# Altium schematic sheet Sheet4.SchDoc
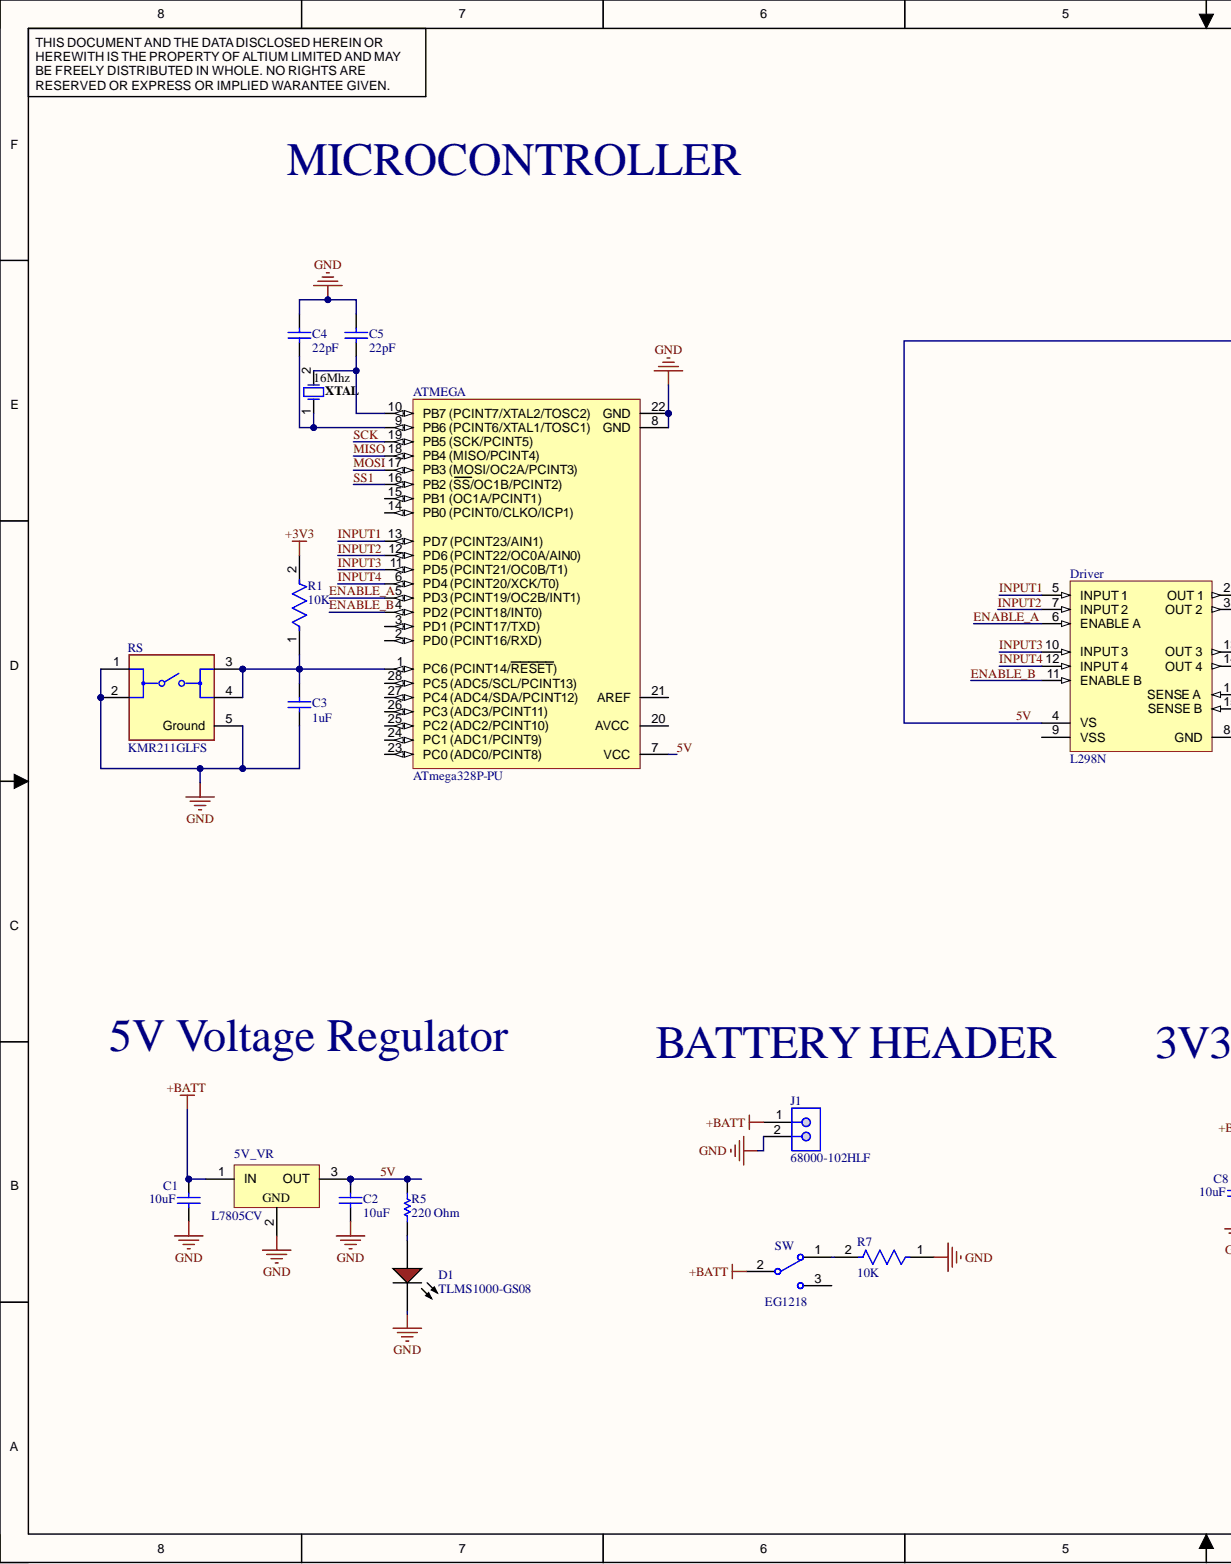
[diagram p0: Sheet4.SchDoc, left]
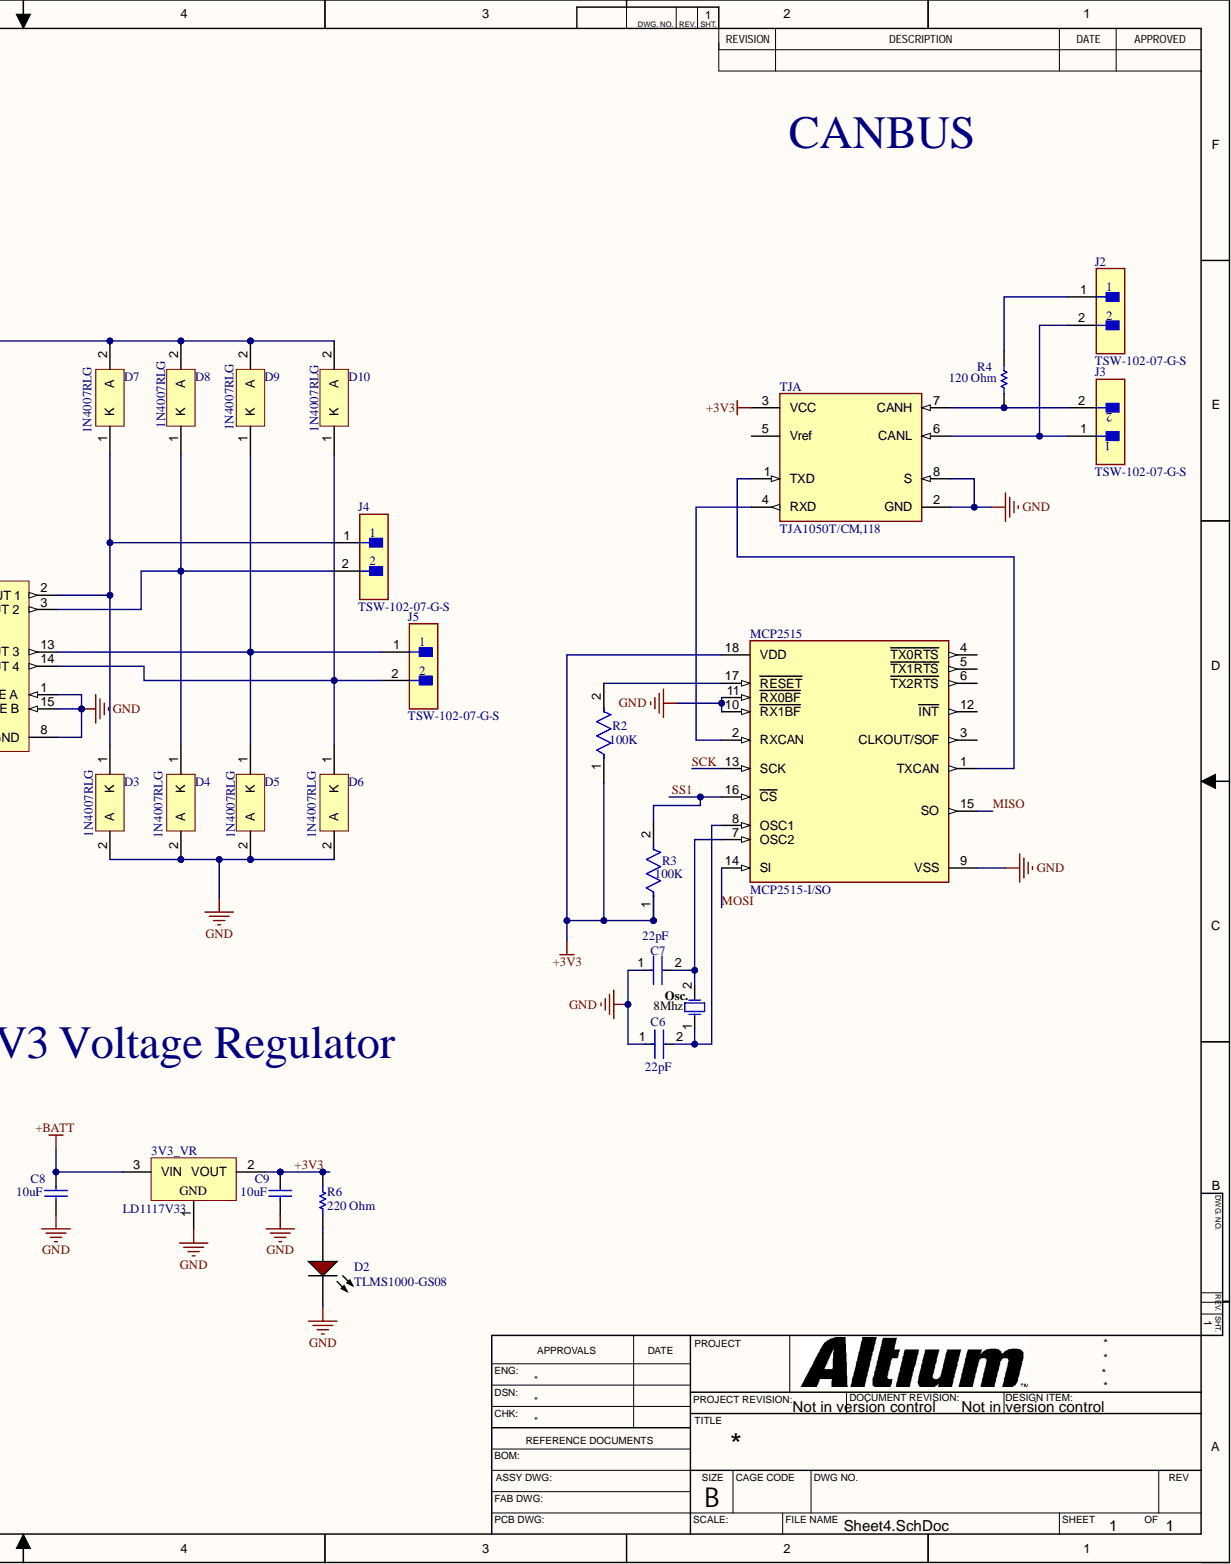
[diagram p1: Sheet4.SchDoc, right]

## Parts
3V3_VR  LD1117V33  [TO220]
5V_VR  L7805CV  [TO220]
ATMEGA  ATmega328P-PU  [28P3]
C1  10uF  [CAPC2013X135X45NL10T25]
C2  10uF  [CAPC2013X135X45NL10T25]
C3  1uF  [CAPC1608X90X35ML10T25]
C4  22pF  [CAPC1508X91X25NL25T13]
C5  22pF  [CAPC1508X91X25NL25T13]
C6  22pF  [FP-C0805C-DN-MFG]
C7  22pF  [FP-C0805C-DN-MFG]
C8  10uF  [CAPC2013X135X45NL10T25]
C9  10uF  [CAPC2013X135X45NL10T25]
D1  TLMS1000-GS08  [VISH-TLMS1000_V]
D2  TLMS1000-GS08  [VISH-TLMS1000_V]
D3  1N4007RLG  [ONSC-AXIAL_LEAD-2-59-10_P]
D4  1N4007RLG  [ONSC-AXIAL_LEAD-2-59-10_P]
D5  1N4007RLG  [ONSC-AXIAL_LEAD-2-59-10_P]
D6  1N4007RLG  [ONSC-AXIAL_LEAD-2-59-10_P]
D7  1N4007RLG  [ONSC-AXIAL_LEAD-2-59-10_P]
D8  1N4007RLG  [ONSC-AXIAL_LEAD-2-59-10_P]
D9  1N4007RLG  [ONSC-AXIAL_LEAD-2-59-10_P]
D10  1N4007RLG  [ONSC-AXIAL_LEAD-2-59-10_P]
Driver  L298N  [STM-FM-15]
J1  68000-102HLF  [AMPH-68000-102HLF_V]
J2  TSW-102-07-G-S  [FP-TSW-102-07-G-S-MFG]
J3  TSW-102-07-G-S  [FP-TSW-102-07-G-S-MFG]
J4  TSW-102-07-G-S  [FP-TSW-102-07-G-S-MFG]
J5  TSW-102-07-G-S  [FP-TSW-102-07-G-S-MFG]
MCP2515  MCP2515-I/SO  [FP-C04-051-MFG]
Osc.  8Mhz  [FOX-FOXSLF080-20]
R1  10K  [FP-CPF0603-MFG]
R2  100K  [FP-CPF0603-MFG]
R3  100K  [FP-CPF0603-MFG]
R4  120 Ohm  [RESC1608X55X25NL10T15]
R5  220 Ohm  [RESC1608X55X25ML10T15]
R6  220 Ohm  [RESC1608X55X25ML10T15]
R7  10K  [FP-CPF0603-MFG]
RS  KMR211GLFS  [FP-KMR211GLFS-MFG]
SW  EG1218  [ESWI-EG1218_V]
TJA  TJA1050T/CM,118  [FP-SOT96-1-IPC_A]
XTAL  16Mhz  [FOX-FOXSLF160-20]

## Nets
+BATT: 3V3_VR.3 5V_VR.1 C1.1 C8.1 J1.1 SW.2
GND: 3V3_VR.1 5V_VR.2 ATMEGA.8(GND) ATMEGA.22(GND) C1.2 C2.2 C3.1 C4.2 C5.2 C6.1 C7.1 C8.2 C9.2 D1.2 D2.2 D3.2 D4.2 D5.2 D6.2 Driver.1(SENSE_A) Driver.8(GND) Driver.15(SENSE_B) J1.2 MCP2515.9(VSS) MCP2515.10(RX1BF) MCP2515.11(RX0BF) R7.1 RS.1 RS.2 RS.5(Ground) TJA.2(GND) TJA.8(S)
5V: 5V_VR.3 ATMEGA.7(VCC) C2.1 D7.2 D8.2 D9.2 D10.2 Driver.4(VS) R5.2
+3V3: 3V3_VR.2 C9.1 MCP2515.18(VDD) R1.2 R2.1 R3.1 R6.2 TJA.3(VCC)
ENABLE_A: ATMEGA.5(PD3_(PCINT19/OC2B/INT1)) Driver.6(ENABLE_A)
ENABLE_B: ATMEGA.4(PD2_(PCINT18/INT0)) Driver.11(ENABLE_B)
INPUT1: ATMEGA.13(PD7_(PCINT23/AIN1)) Driver.5(INPUT_1)
INPUT2: ATMEGA.12(PD6_(PCINT22/OC0A/AIN0)) Driver.7(INPUT_2)
INPUT3: ATMEGA.11(PD5_(PCINT21/OC0B/T1)) Driver.10(INPUT_3)
INPUT4: ATMEGA.6(PD4_(PCINT20/XCK/T0)) Driver.12(INPUT_4)
MISO: ATMEGA.18(PB4_(MISO/PCINT4)) MCP2515.15(SO)
MOSI: ATMEGA.17(PB3_(MOSI/OC2A/PCINT3)) MCP2515.14(SI)
SCK: ATMEGA.19(PB5_(SCK/PCINT5)) MCP2515.13(SCK)
SS1: ATMEGA.16(PB2_(SS/OC1B/PCINT2)) MCP2515.16(CS) R3.2
NetATMEGA_1: ATMEGA.1(PC6_(PCINT14/RESET)) C3.2 R1.1 RS.3 RS.4
NetATMEGA_9: ATMEGA.9(PB6_(PCINT6/XTAL1/TOSC1)) C4.1 XTAL.1
NetATMEGA_10: ATMEGA.10(PB7_(PCINT7/XTAL2/TOSC2)) C5.1 XTAL.2
NetC6_2: C6.2 MCP2515.8(OSC1) Osc..1
NetC7_2: C7.2 MCP2515.7(OSC2) Osc..2
NetD1_1: D1.1 R5.1
NetD2_1: D2.1 R6.1
NetD3_1: D3.1 D7.1 Driver.2(OUT_1) J4.1
NetD4_1: D4.1 D8.1 Driver.3(OUT_2) J4.2
NetD5_1: D5.1 D9.1 Driver.13(OUT_3) J5.1
NetD6_1: D6.1 D10.1 Driver.14(OUT_4) J5.2
NetJ2_1: J2.1 R4.2
NetJ2_2: J2.2 J3.1 TJA.6(CANL)
NetJ3_2: J3.2 R4.1 TJA.7(CANH)
NetMCP2515_1: MCP2515.1(TXCAN) TJA.1(TXD)
NetMCP2515_2: MCP2515.2(RXCAN) TJA.4(RXD)
NetMCP2515_17: MCP2515.17(RESET) R2.2
NetR7_2: R7.2 SW.1

Not connected: ATMEGA 2(PD0_(PCINT16/RXD)) 3(PD1_(PCINT17/TXD)) 14(PB0_(PCINT0/CLKO/ICP1)) 15(PB1_(OC1A/PCINT1)) 20(AVCC) 21(AREF) 23(PC0_(ADC0/PCINT8)) 24(PC1_(ADC1/PCINT9)) 25(PC2_(ADC2/PCINT10)) 26(PC3_(ADC3/PCINT11)) 27(PC4_(ADC4/SDA/PCINT12)) 28(PC5_(ADC5/SCL/PCINT13)); Driver 9(VSS); MCP2515 3(CLKOUT/SOF) 4(TX0RTS) 5(TX1RTS) 6(TX2RTS) 12(INT); SW 3; TJA 5(Vref)

## Library data of the parts
3V3_VR = CMP-0244-00470-1: Fixed Low Drop Positive Voltage Regulator, 3.3V, 3-Pin TO-220; Component Kind: Standard; ComponentLink1Description: Manufacturer URL; ComponentLink1URL: http://www.st.com; ComponentLink2Description: Datasheet; ComponentLink2URL: http://www.st.com/internet/com/TECHNICAL_RESOURCES/TECHNICAL_LITERATURE/DATASHEET/CD00000544.pdf; ComponentLink3Description: Package Specification; ComponentLink3URL: http://www.st.com/internet/com/TECHNICAL_RESOURCES/TECHNICAL_LITERATURE/PACKAGE_INFORMATION/CD00004649.pdf; DatasheetVersion: 1/11/2011; Library Name: Altium Content Vault; PackageDescription: TO, Thru-Hole, Vertical, Heatsink Mounted; 3 In-Line Leads; Pitch 2.54 mm; PackageReference: TO220; PackageVersion: ver3.0
5V_VR = CMP-0244-00483-1: Positive Voltage Regulator, 5V, 3-Pin TO-220; Component Kind: Standard; ComponentLink1Description: Manufacturer URL; ComponentLink1URL: http://www.st.com; ComponentLink2Description: Datasheet; ComponentLink2URL: http://www.st.com/internet/com/TECHNICAL_RESOURCES/TECHNICAL_LITERATURE/DATASHEET/CD00000444.pdf; ComponentLink3Description: Package Specification; ComponentLink3URL: http://www.st.com/internet/com/TECHNICAL_RESOURCES/TECHNICAL_LITERATURE/PACKAGE_INFORMATION/CD00004649.pdf; DatasheetVersion: 16/11/2011; Library Name: Altium Content Vault; PackageDescription: TO, Thru-Hole, Vertical, Heatsink Mounted; 3 In-Line Leads; Pitch 2.54 mm; PackageReference: TO220; PackageVersion: ver3.0
ATMEGA = CMP-0095-00013-2: 8-bit AVR Microcontroller, 32KB Flash, 1KB EEPROM, 2KB SRAM, 28-pin PDIP, Industrial Grade (-40°C to 85°C); Component Kind: Standard; ComponentLink1Description: Manufacturer URL; ComponentLink1URL: http://www.atmel.com/; ComponentLink2Description: ATmega48A/48PA/88A/88PA/168A/168PA/328/328P Datasheet; ComponentLink2URL: http://www.atmel.com/dyn/resources/prod_documents/8271.pdf; ComponentLink3Description: ATmega48A/48PA/88A/88PA/168A/168PA/328/328P Summary; ComponentLink3URL: http://www.atmel.com/dyn/resources/prod_documents/8271S.pdf; DatasheetVersion: revD, May-2011; Library Name: Altium Content Vault; PackageDescription: 28-lead (0.300"/7.62mm Wide) Plastic Dual Inline Package (PDIP); PackageReference: 28P3; PackageVersion: revB, Sep-2001; RevisionNote: DatasheetVersion updated. URLs added.
C1 C2 C8 C9 = CMP-2007-03463-1: Manufacturer: Murata; Part Number: GRM21BR61A106KE19K; Component Kind: Standard; Library Name: Altium Content Vault
C3 = CMP-2000-05447-1: Chip Capacitor, 1 uF, +/- 10%, 50 V, -55 to 85 degC, 0603 (1608 Metric), RoHS, Tape and Reel; Manufacturer: Taiyo Yuden; Capacitance: 1µF; Catalog Drawings: xMKSeries_0603_0.8; Online Catalog: MSeries; Other Names: 587-2400-6; Temperature Coefficient: X5R; Voltage - Rated: 50V; Applications: GeneralPurpose; Category: Capacitors; Component Kind: Standard; Datasheet URL: https://datasheet.ciiva.com/15922/336umk107bj105ka-t-15922611.pdf; Datasheet Version: Mar-13; Family: CeramicCapacitors; Features: -; Height - Seated (Max): -; Lead Spacing: -; Lead Style: -; Library Name: Altium Content Vault; Manufacturer URL: http://www.t-yuden.com/; Mounting Type: SurfaceMount,MLCC; Operating Temperature: -55degC~85degC; Package / Case: 0603(1608Metric); Package Description: 2-Pin Surface Mount Device, Body 1.6 x 0.8 mm; Package Reference: 603; Packaging: Digi-Reel; Ratings: -; Series: M; Size / Dimension: 0.063"Lx0.031"W(1.60mmx0.80mm); Standard Package: 1; Thickness (Max): 0.035"(0.90mm); Tolerance: ±10%
C4 C5 = CMP-2006-04643-1: Manufacturer: AVX Interconnect / Elco; Part Number: 06031U220FAT2A; Component Kind: Standard; Library Name: Altium Content Vault
C6 C7 = CMP-2007-00466-2: CAP CER 22PF 50V 10% NP0 0805; Manufacturer: KEMET; Manufacturer Part Number: C0805C220K5GACTU; Material: Ceramic; Temperature Characteristic: C0G; Temperature Coefficient: 30ppm/°C; Tolerance: 0.1; Automotive: No; Capacitance: 22 pF; Category: Cap; Component Kind: Standard; ComponentLink2Description: Datasheet; ComponentLink2URL: https://content.kemet.com/datasheets/KEM_C1003_C0G_SMD.pdf; Device Class L1: Passive Components; Device Class L2: Capacitors; Device Class L3: Ceramic Capacitors; Digikey Description: CAP CER 22PF 50V 10% NP0 0805; Height: 0.88mm; Ipc Land Pattern Name: CAPC200125X78; Lead Free: Yes; Library Name: Altium Content Vault; Mouser Description: Multilayer Ceramic Capacitors MLCC - SMD/SMT 50volts 22pF C0G 10%; Octopart: https://octopart.com/c0805c220k5gactu-kemet-9138623; Package: C0805C-DN; RoHS: TRUE; Temperature: -55°C to +125°C; Temperature Range High: +125°C; Temperature Range Low: -55°C; Value: 22 pF; Voltage Rating: 50 V
D1 D2 = CMP-2000-07254-1: Low Current LED, 40 mW, 1.8 V, -40 to 100 degC, 2-Pin SMD (0603), RoHS, Tape and Reel; Manufacturer: Vishay; Automotive Qualification Standard: AEC-Q100; Bulb Size: 1.6mmx0.8mm; Forward Current If: 2mA; Forward Voltage: 1.8V; LED Colour: Red; Lens Shape: Rectangular; Luminous Intensity: 4mcd; SVHC: ToBeAdvised; Viewing Angle: 80°; Wavelength Typ: 636nm; Component Kind: Standard; Datasheet URL: https://datasheet.ciiva.com/5798/1698881-5798337.pdf; Datasheet Version: Rev. 1.7, 02/2011; LED Mounting: SMD; Library Name: Altium Content Vault; Manufacturer URL: http://www.vishay.com/; Mounting Technology: SurfaceMount; MSL: MSL2-1year; Package Description: 2-Pin Surface Mount Device, Body 1.6 x 0.8 mm; Package Reference: TLMS1000; Packaging: CutTape; Product Range: -
D3-D10 = CMP-0902-00147-1: Standard Recovery Rectifier, 2-Pin Axial_Lead, Pb-Free, Tape and Reel; Manufacturer: On Semiconductor; IFSM Max (A): 30; IO(rec) Max (A): 1; VRRM Max (V): 1000; Component Kind: Standard; ComponentLink1Description: Manufacturer URL; ComponentLink1URL: http://www.onsemi.com/; ComponentLink2Description: Datasheet; ComponentLink2URL: http://www.onsemi.com/pub_link/Collateral/1N4001-D.PDF; ComponentLink3Description: Package Specification; ComponentLink3URL: http://www.onsemi.cn/pub_link/Collateral/59-10.PDF; DatasheetVersion: Rev. 13; Library Name: Altium Content Vault; Mounting Technology: Through Hole; PackageDescription: 2-Pin, Axial Lead, Diameter 2.35 mm; PackageReference: AXIAL_LEAD-2-59-10; PackageVersion: Rev. U, 02/2005; Packing: Tape and Reel; PartNumber: 1N4007RLG; RoHS: TRUE; Type: Standard Recovery
Driver = CMP-2000-06526-1: Dual Full-Bridge Driver, 4.8 to 46 V, -40 to 150 degC, 15-Pin FM (MW 15L), RoHS, Tube; Manufacturer: STMicroelectronics; PartNumber: L298; Driver Case Style: Multiwatt; Motor Type: FullBridge; No. of Outputs: 4Outputs; No. of Pins: 15Pins; Output Current: 2A; Output Voltage: 46V; Supply Voltage Max: 7V; Supply Voltage Min: 4.5V; SVHC: NoSVHC(17-Dec-2015); Automotive Qualification Standard: -; Component Kind: Standard; Datasheet URL: https://datasheet.ciiva.com/15109/getdatasheetpartid-50682-15109332.pdf; Datasheet Version: 01/2000; Library Name: Altium Content Vault; Manufacturer URL: http://www.st.com/; Mounting Technology: ThroughHole; MSL: -; Operating Temperature Max: 130°C; Operating Temperature Min: -25°C; Package Description: 15-Pin Flange Mount, Body 5 x 19.6 mm, Pitch 1.27 mm; Package Reference: FM-15; Packaging: Each; Product Range: -
J1 = CMP-2000-05666-1: Male Header, Pitch 2.54 mm, 1 x 2 Position, Height 8.38 mm, Tail Length 2.41 mm, RoHS, Bulk; Brand: FCI; Current Rating: 3A; Insulation Resistance: 5000MOhms; Mating Post Length: 5.84mm; Mounting Angle: Vertical; Number of Positions: 2; Pitch: 2.54mm; Voltage Rating: 1.5kV; Component Kind: Standard; Contact Gender: Pin(Male); Contact Material: PhosphorBronze; Contact Plating: Gold/GTX; Datasheet URL: https://datasheet.ciiva.com/5149/68000-274228-5149165.pdf; Datasheet Version: Rev. F, 04/2006; Factory Pack Quantity: 100; Flammability Rating: UL94V-0; Housing Material: Thermoplastic; Library Name: Altium Content Vault; Manufacturer: FCI; Manufacturer URL: http://www.amphenol.com/; Mounting Style: ThroughHole; Mounting Technology: ThroughHole; Number of Positions / Contacts: 2; Number of Rows: 1; Operating Temperature Range: -65Cto+125C; Package Description: Male Header, Pitch 2.54 mm, 1 x 2 Position, Height 8.38 mm, Tail Length 2.41 mm; Package Reference: 68000-102HLF; Packaging: Bulk; Product Category: Headers&WireHousings; Product Type: Headers; RoHS: Details; Series: 68000; Termination Post Length: 2.41mm; Termination Style: ThroughHole; Type: Unshrouded
J2-J5 = CMP-02766-000084-1: CONN HEADER VERT 2POS 2.54MM; Manufacturer: Samtec; Conn Gender: Male; Conn Orientation: Straight; Current Rating: 6.3A; Number Of Contacts: 2; Voltage Rating: 550V; Automotive: No; Category: Conn; Component Kind: Standard; ComponentLink2Description: Datasheet; ComponentLink2URL: http://suddendocs.samtec.com/catalog_english/tsw_th.pdf; Contact Material: Gold,Nickel; Device Class L1: Connectors; Device Class L2: Headers and Wire Housings; Device Class L3: unset; Digikey Description: CONN HEADER VERT 2POS 2.54MM; Footprint Url: http://suddendocs.samtec.com/prints/tsw-xxx-xx-x-x-xx-xxx-footprint.pdf; Height: 8.71mm; Lead Free: Yes; Library Name: Altium Content Vault; Manufacturer Part Number: TSW-102-07-G-S; Mouser Description: Conn Unshrouded Header HDR 2 POS 2.54mm Solder ST Thru-Hole Automotive Bulk; Number Of Rows: 1; Octopart: https://octopart.com/tsw-102-07-g-s-samtec-272197; Package: TSW-102-07-G-S; Pitch: 2.54mm; RoHS: TRUE; Temperature: -55°C to +125°C; Temperature Range High: +125°C; Temperature Range Low: -55°C
MCP2515 = CMP-0186-00133-3: IC CAN CONTROLLER W/SPI 18SOIC; Manufacturer: Microchip; Interface: SPI,CAN; Max Supply Voltage: 5.5V; Min Supply Voltage: 2.7V; Nominal Supply Current: 10mA; Standoff Height: 0.1mm; Ambient Temperature Range High: +125°C; Ambient Temperature Range Low: -65°C; Automotive: No; Category: IC; Component Kind: Standard; ComponentLink2Description: Datasheet; ComponentLink2URL: http://ww1.microchip.com/downloads/en/DeviceDoc/MCP2515-Stand-Alone-CAN-Controller-with-SPI-20001801J.pdf; Device Class L1: Integrated Circuits (ICs); Device Class L2: Interface ICs; Device Class L3: Controllers; Digikey Description: IC CAN CONTROLLER W/SPI 18SOIC; Footprint Url: http://ww1.microchip.com/downloads/en/DeviceDoc/18L_SOIC_Wide_7.50_SO_C04-0051D.pdf; Function: Controller; Height: 2.65mm; Ipc Land Pattern Name: SOIC127P1030X265-18; Lead Free: Yes; Library Name: Altium Content Vault; Manufacturer Part Number: MCP2515-I/SO; Mouser Description: CAN Interface IC W/ SPI Interface; Octopart: https://octopart.com/mcp2515-i%2Fso-microchip-430187; Package: C04-051; RoHS: TRUE; Temperature: -40°C to +85°C; Temperature Range High: +85°C; Temperature Range Low: -40°C
Osc. = CMP-1749-00002-1: Resistance Weld Thru-Hole Crystal, 8 MHz, -20 to 70 degC, 2-Pin THD, RoHS, Bulk; Case\Package: TO-263-3; Dropout Voltage: 2 V; Max Input Voltage: 35 V; Max Ouput Current: 1 A; Min Input Voltage: 7 V; Nominal Output Voltage: 5 V; Outputs: 1; Component Kind: Standard; ComponentLink1Description: Manufacturer URL; ComponentLink1URL: http://www.foxonline.com/; ComponentLink2Description: Datasheet; ComponentLink2URL: http://download.siliconexpert.com/pdfs/2015/4/5/6/5/7/200/fox_/manual/35607121449963728hc49slf.pdf; DatasheetVersion: Mar-15; Height: 30.02 mm; Length: 10.24 mm; Library Name: Altium Content Vault; Max Operating Temperature: 125 degC; Min Operating Temperature: 0 degC; Mount: Surface Mount; Output Current: 1 A; Output Voltage: 5 V; Package Quantity: 50; PackageDescription: 2-Pin Through Hole Device, Body 11.35 x 4.65 mm; PackageReference: FOXSLF080-20; Packaging: Rail/Tube; Pins: 3; Radiation Hardening: No; REACH SVHC: No SVHC; RoHSCompliant: true; Width: 9.35 mm
R1 R7 = CMP-03211-008304-1: RES SMD 10KΩ 1% 1/16W 0603; Manufacturer: TE Connectivity; Manufacturer Part Number: CPF0603F10KC1; Power Rating: 0.063W; Temperature Coefficient: 50ppm/°C; Voltage Rating: 50V; Automotive: No; Category: Res; Component Kind: Standard; ComponentLink2Description: Datasheet; ComponentLink2URL: https://www.te.com/commerce/DocumentDelivery/DDEController?Action=showdoc&DocId=Data+Sheet%7F1773200%7FM%7Fpdf%7FEnglish%7FENG_DS_1773200_M.pdf%7F3-1676481-2; Composition: Thin Film; Device Class L1: Passive Components; Device Class L2: Resistors; Device Class L3: Chip SMD Resistors; Height: 0.55mm; Ipc Land Pattern Name: RESC15580X45; Lead Free: Yes; Library Name: Altium Content Vault; Octopart: https://octopart.com/cpf0603f10kc1-te+connectivity-42013076; octopart_url: https://octopart.com/cpf0603f10kc1-te+connectivity-42013076; Package: CPF0603; packaging: 1k Reel; Resistance: 10KΩ; RoHS: TRUE; Series: CPF; Temperature: -55°C to +155°C; Temperature Range High: +155°C; Temperature Range Low: -55°C; Tolerance: 1%; Value: 10KΩ
R2 R3 = CMP-03211-008043-1: RES SMD 100KΩ 0.1% 1/16W 0603; Manufacturer: TE Connectivity; Manufacturer Part Number: CPF0603B100KE1; Power Rating: 0.063W; Temperature Coefficient: 25ppm/°C; Voltage Rating: 50V; Automotive: No; Category: Res; Component Kind: Standard; ComponentLink2Description: Datasheet; ComponentLink2URL: https://www.te.com/commerce/DocumentDelivery/DDEController?Action=showdoc&DocId=Data+Sheet%7F1773200%7FM%7Fpdf%7FEnglish%7FENG_DS_1773200_M.pdf%7F3-1676481-2; Composition: Thin Film; Device Class L1: Passive Components; Device Class L2: Resistors; Device Class L3: Chip SMD Resistors; Height: 0.55mm; Ipc Land Pattern Name: RESC15580X45; Lead Free: Yes; Library Name: Altium Content Vault; Octopart: https://octopart.com/cpf0603b100ke1-te+connectivity-9539443; octopart_url: https://octopart.com/cpf0603b100ke1-te+connectivity-9539443; Package: CPF0603; packaging: 1k Reel; Resistance: 100KΩ; RoHS: TRUE; Series: CPF; Temperature: -55°C to +155°C; Temperature Range High: +155°C; Temperature Range Low: -55°C; Tolerance: 0.1%; Value: 100KΩ
R4 = CMP-1659-00034-1: Chip Resistor, 120 Ohm, +/- 1%, 100 mW, -55 to 155 degC, 0603 (1608 Metric), RoHS, Tape and Reel; Case\Package: 0603; Forward Current: 20 mA; Forward Voltage: 2.1 V; Illumination Colour: Orange; Lens Style: Diffused; Luminous Intensity: 80 mcd; Nominal Wavelength: 606 nm; Power Rating: 90 mW; Viewing Angle: 160 degrees; Case Code (Imperial): 0603; Case Code (Metric): 1608; Colour: Orange; Component Kind: Standard; ComponentLink1Description: Manufacturer URL; ComponentLink1URL: http://www.yageo.com/; ComponentLink2Description: Datasheet; ComponentLink2URL: http://www.yageo.com/documents/recent/PYu-RC_Group_51_RoHS_L_04.pdf; DatasheetVersion: Rev. 4, 02/2016; Height: 0.6 mm; Length: 1.6 mm; Library Name: Altium Content Vault; Max Operating Temperature: 100 degC; Min Operating Temperature: -40 degC; Mount: Surface Mount; Nominal Vf: 2.1 V; Package Quantity: 3000; PackageDescription: 2-Pin Surface Mount Device, Body 1.6 x 0.8 mm; PackageReference: 0603; Packaging: Tape and Reel; Pins: 2; Radiation Hardening: No; REACH SVHC: Unknown; RoHSCompliant: true; Width: 0.8 mm
R5 R6 = CMP-1659-00018-2: General Purpose Chip Resistors, 220 Ohm, +/- 1%, -55 to 155 degC, 0603 (1608 Metric), RoHS, Tape and Reel; Component Kind: Standard; ComponentLink1Description: Manufacturer URL; ComponentLink1URL: http://www.yageo.com/; ComponentLink2Description: Datasheet; ComponentLink2URL: https://datasheet.ciiva.com/11808/0900766b80f96399-11808136.pdf; DatasheetVersion: Rev. 4, 04/2009; Library Name: Altium Content Vault; PackageDescription: 2-Pin Surface Mount Device, Body 1.6 x 0.8 mm; PackageReference: 0603; RoHSCompliant: false
RS = CMP-10040-000023-1: SWITCH TACTILE SPST-NO 0.05A 32V; Actuator Length: 0.5mm; Actuator Type: Standard; Contact Current Rating: 50mA; Illumination Type: Non-Illuminated; Mechanical Life: 200000Cycles; Operating Force: 1.2N; Power Rating: 1W; Switch Function: Off-Mom; Switch Travel: 0.2mm; Throw Configuration: SPST; Component Kind: Standard; Contact Material: Silver; Contact Plating: Silver; Current Rating: 50mA; Depth: 4.6mm; Lead Free: Lead Free; Length: 2.8mm; Library Name: Altium Content Vault; Max Current Rating: 50mA; Max Operating Temperature: 85°C; Max Voltage Rating (DC): 32V; Min Operating Temperature: -40°C; Mount: Surface Mount; Orientation: Straight; Package Quantity: 1; Packaging: Tape and Reel; Radiation Hardening: No; REACH SVHC: No SVHC; Termination: Gull Wing; Voltage Rating (DC): 32V; Width: 2.8mm
SW = CMP-1696-00002-1: Slide Switch, 500 V, -20 to 70 degC, 3-Pin THD, RoHS; Case\Package: SOT-23-5; Dropout Voltage: 300 mV; Max Input Voltage: 8 V; Max Ouput Current: 150 mA; Max Power Dissipation: 250 mW; Min Input Voltage: 4.3 V; Nominal Output Voltage: 3.3 V; Outputs: 1; Component Kind: Standard; ComponentLink1Description: Manufacturer URL; ComponentLink1URL: http://www.e-switch.ch/en/; ComponentLink2Description: Datasheet; ComponentLink2URL: https://www.e-switch.com/system/asset/product_line/data_sheet/119/EG.pdf; DatasheetVersion: Oct-15; Height: 1.3 mm; Length: 3 mm; Library Name: Altium Content Vault; Max Operating Temperature: 85 degC; Min Operating Temperature: -40 degC; Mount: Surface Mount; Output Current: 150 mA; Output Voltage: 3.3 V; Package Quantity: 3000; PackageDescription: 3-Pin Through Hole Device, Body 11.6 x 4 mm, Pitch 2.5 mm; PackageReference: EG1218; Packaging: Tape and Reel; Pins: 5; Power Rating: 250 mW; Radiation Hardening: No; REACH SVHC: No SVHC; RoHSCompliant: true; Width: 1.8 mm
TJA = CMP-2000-04923-2: IC TRANSCEIVER HALF 1/1 8SO; Data Rate: 1Mbps; Interface: CAN; Max Supply Current: 10mA; Max Supply Voltage: 5.25V; Min Supply Voltage: 4.75V; Number of Receive Buffers: 1; Receiver Hysteresis: 70mV; Simplex/Duplex: Half Duplex; Case/Package: SO; Component Kind: Standard; Contact Plating: Gold; Lead Free: Lead Free; Library Name: Altium Content Vault; Max Operating Temperature: 150°C; Min Operating Temperature: -40°C; Mount: Surface Mount; Nominal Supply Current: 10mA; Number of Pins: 8; Number of Transceivers: 1; Number of Transmit Buffers: 1; Package Quantity: 2500; Packaging: Tape and Reel; Radiation Hardening: No; REACH SVHC: No SVHC
XTAL = CMP-1749-00003-1: Resistance Weld Thru-Hole Crystal, 16 MHz, -20 to 70 degC, 2-Pin THD, RoHS, Bulk; Case\Package: Axial; Power Rating: 250 mW; Resistance: 221 kohm; Tolerance: 1%; Voltage Rating: 250 V; Component Kind: Standard; ComponentLink1Description: Manufacturer URL; ComponentLink1URL: http://www.foxonline.com/; ComponentLink2Description: Datasheet; ComponentLink2URL: http://www.foxonline.com/pdfs/hc49slf.pdf; DatasheetVersion: Mar-15; Length: 0.248 inch; Library Name: Altium Content Vault; Max Operating Temperature: 155 degC; Min Operating Temperature: -55 degC; Mount: Through Hole; Package Quantity: 200; PackageDescription: 2-Pin Through Hole Device, Body 11.35 x 4.65 mm; PackageReference: FOXSLF160-20; Packaging: Bulk; RoHSCompliant: true
